# Altium schematic sheet Schem, Transadmittance Amp_rev_E.SchDoc
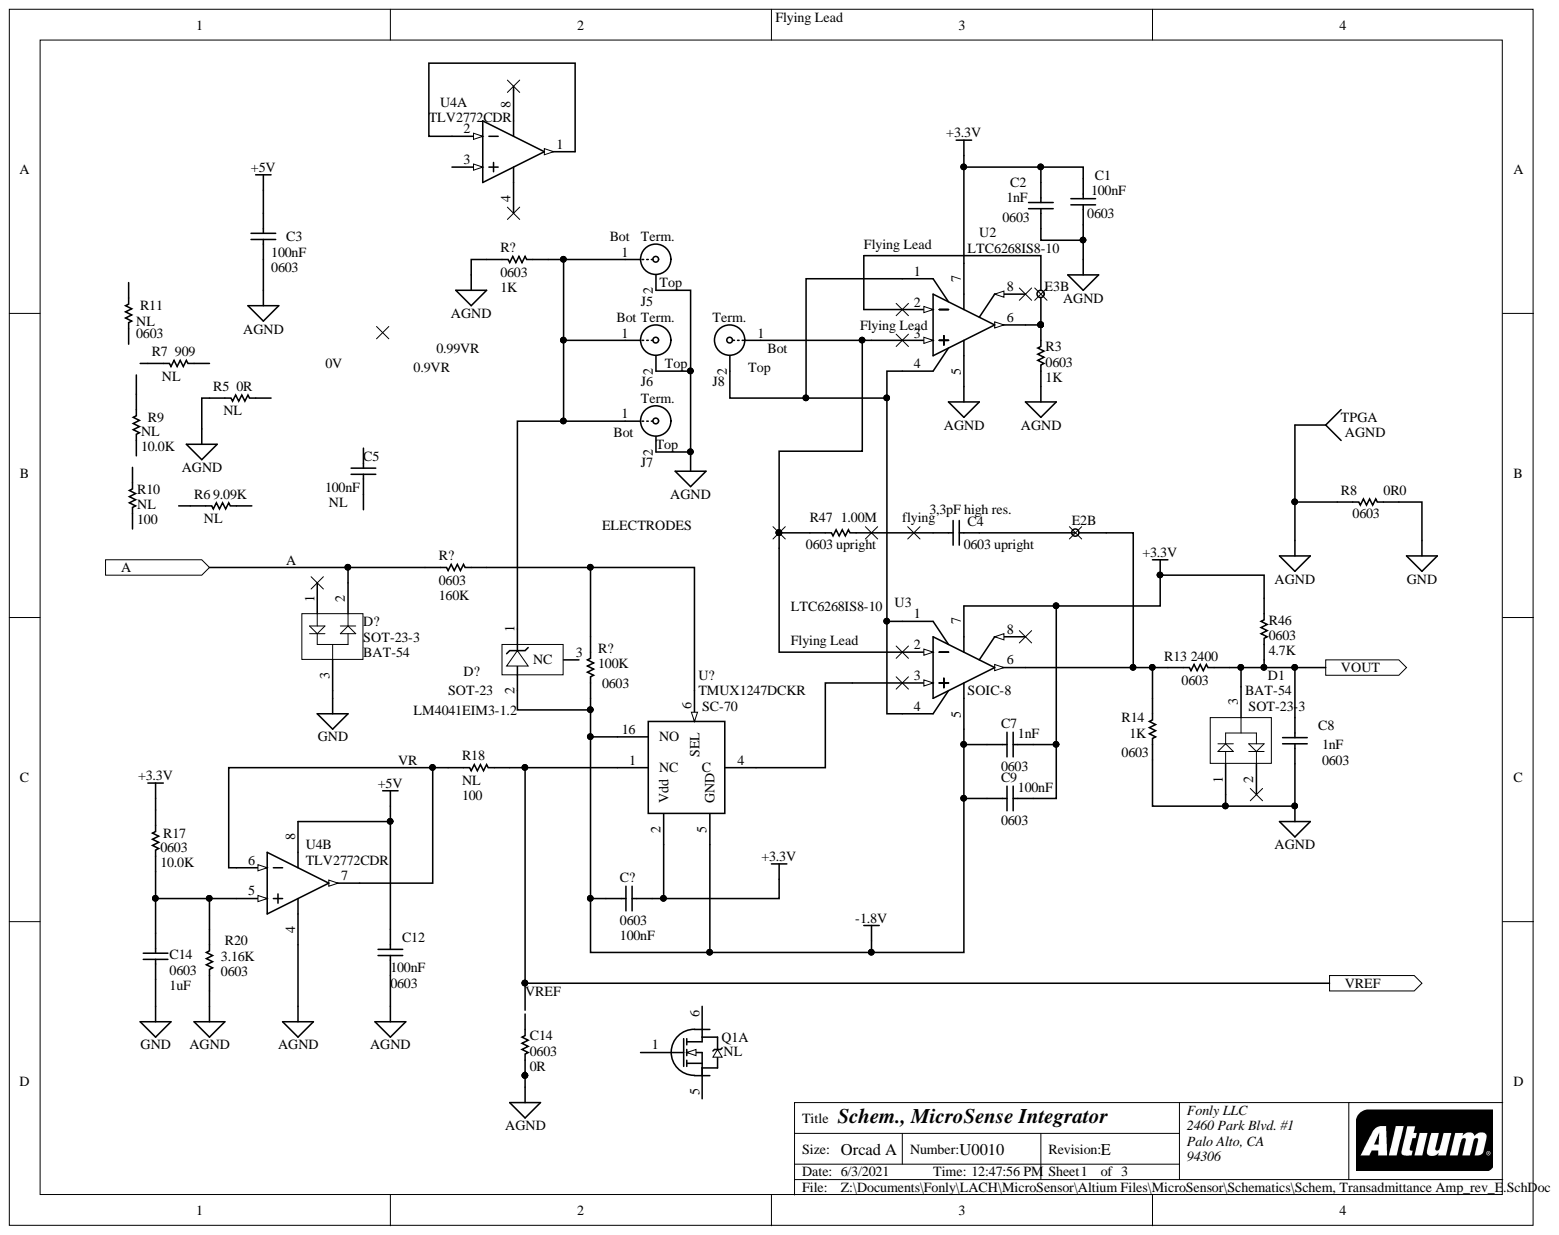
[diagram p0: Schem, Transadmittance Amp_rev_E.SchDoc]

## Parts
C1  0603  value 100nF  [1608[0603]]
C2  0603  value 1nF  [1608[0603]]
C3  0603  value 100nF  [1608[0603]]
C4  0603 upright  value 3,3pF high res.  [1608[0603]]
C5  NL  value 100nF  [1608[0603]]
C7  0603  value 1nF  [1608[0603]]
C8  0603  value 1nF  [1608[0603]]
C9  0603  value 100nF  [1608[0603]]
C12  0603  value 100nF  [1608[0603]]
C14  0603  value 0R  [RESC1608L]
C14  0603  value 1uF  [1608[0603]]
C?  0603  value 100nF  [1608[0603]]
D1  SOT-23-3  MFG_P/N: BAT-54  [DIOD-SOT23-3_V]
D?  SOT-23  [MF03A_N]
D?  SOT-23-3  MFG_P/N: BAT-54  [DIOD-SOT23-3_V]
E2  Socket  [SCKT-1.05H1]  (value not drawn)  (part B)
E3  Socket  [SCKT-1.05H1]  (value not drawn)  (part B)
J5  Coax endpoint  [Coaxial Terminal]  (value not drawn)
J6  Coax endpoint  [Coaxial Terminal]  (value not drawn)
J7  Coax endpoint  [Coaxial Terminal]  (value not drawn)
J8  Coax endpoint  [Coaxial Terminal]  (value not drawn)
Q1  NL  [SC-74]  (part A)
R3  0603  value 1K  [RESC1608L]
R5  NL  value 0R  [RESC1608L]
R6  NL  value 9.09K  [RESC1608L]
R7  NL  value 909  [RESC1608L]
R8  0603  value 0R0  [RESC1608L]
R9  NL  value 10.0K  [RESC1608L]
R10  NL  value 100  [RESC1608L]
R11  0603  value NL  [RESC1608L]
R13  0603  value 2400  [RESC1608L]
R14  0603  value 1K  [RESC1608L]
R17  0603  value 10.0K  [RESC1608L]
R18  NL  value 100  [RESC1608L]
R20  0603  value 3.16K  [RESC1608L]
R46  0603  value 4.7K  [RESC1608L]
R47  0603 upright  value 1.00M  [RESC1608L]
R?  0603  value 1K  [RESC1608L]
R?  0603  value 160K  [RESC1608L]
R?  0603  value 100K  [RESC1608L]
TPGA  AGND  [PIN1]
U2  MFG_P/N: LTC6268IS8-10  [LTC6268-SO8]  (hidden comment: SOIC-8)
U3  SOIC-8  MFG_P/N: LTC6268IS8-10  [LTC6268-SO8]
U4  TLV2772CDR  [D0008A_N]  (parts A,B)
U?  SC-70  PartNo: TMUX1247DCKR

## Ports
A, VOUT, VREF

## Nets
+3.3V: C1.1 C2.1 C7.2 C9.2 C?.2 R17.1 R46.1 U2.7 U3.7 U?.2(Vdd)
+5V: C3.1 C12.1 U4.8
-1.8V: C7.1 C9.1 C?.1 D?.2 R?.2 U3.5 U?.5(GND) U?.16(NO)
AGND: C1.2 C2.2 C3.2 C8.2 C12.2 C14.2 D1.1 J5.2 J6.2 J7.2 R3.2 R5.2 R8.1 R14.2 R20.2 R?.1 TPGA.1 U2.5 U4.4
GND: C14.2 D?.3 R8.2
A: D?.2 R?.1 ; port A
VOUT: C8.1 D1.3 R13.1 R46.2 ; port VOUT
VR: R18.1 U4.6 U4.7
VREF: R18.2 U?.1(NC) ; port VREF
NetC4_1: C4.1 R47.2
NetC14_1: C14.1 R17.2 R20.1 U4.5
NetD?_1: D?.1 J5.1 J6.1 J7.1 R?.2
NetE2_1: E2.1 R13.2 R14.1 U3.6
NetE3_1: E3.1 R3.1 U2.6
NetJ8_1: J8.1 R47.1
NetJ8_2: J8.2 U2.1 U2.4 U3.1 U3.4
NetR?_1: R?.1 R?.2 U?.6(SEL)
NetU4_1: U4.1 U4.2
0.9VR:
0.99VR:
0V:

Not connected: C4 2; C5 1 2; D1 2; Q1 1 5 6; R5 1; R6 1 2; R7 1 2; R9 1 2; R10 1 2; R11 1 2; U2 2 3 8; U3 2 3 8; U4 3; U? 4(C)

## Library data of the parts
C1 C3 C5 C9 C12 C? = Cap Semi: Capacitor Ceramic, SMD, 0603, 0.1uF, 16V, 10%; MFG: Samsung; MFG_P/N: CL10B104KO8NNWC; Vendor: DigiKey; Vendor P/N: 1276-1939-1-ND; Component Kind: Standard; Library Name: Miscellaneous Devices.SchLib; Signal Integrity: Cap Semi; Simulation: CAP
C2 C7 C8 = Cap Semi: Capacitor Ceramic, SMD, 0603, C0G, 1nF, 50V, 5%; MFG: Samsung; MFG_P/N: CL10C102JB8NFNC; Vendor: DigiKey; Vendor P/N: 1276-2170-1-ND; Component Kind: Standard; Library Name: Miscellaneous Devices.SchLib; Signal Integrity: Cap Semi; Simulation: CAP
C4 = Cap Semi: Cap., ceramic, ultra-lo ESR, 3.3pF 10^12 ohm; MFG: AVX; MFG_P/N: MK05V7270JAT2A; Vendor: Mouser; Vendor P/N: 581-MK05V7270JAT2A; Component Kind: Standard; Library Name: Miscellaneous Devices.SchLib; Signal Integrity: Cap Semi; Simulation: CAP
C14 R5 = Res1: Resistor; MFG: Panasonic; MFG_P/N: ERJ-3GEY0R00V; Vendor: DigiKey; Vendor2: Mouser; Vendor2 P/N: 667-ERJ-3EKF9092V; Vendor P/N: P0.0GCT-ND; Component Kind: Standard; Library Name: Miscellaneous Devices.SchLib; Signal Integrity: Res; Simulation: RESISTOR
C14 = Cap Semi: Capacitor Ceramic, SMD, 0603, .01uF, 16V, 10%; MFG: Kemet; MFG_P/N: C0603C105M3RACTU; Vendor: DigiKey; Vendor P/N: 399-7377-1-ND; Component Kind: Standard; Library Name: Miscellaneous Devices.SchLib; Signal Integrity: Cap Semi; Simulation: CAP
D1 D? = CMP-1667-00006-1: Surface Mount Schottky Barrier Diode, -65 to 150 degC, 3-Pin SOT23, RoHS, Tape and Reel; Colour: Orange; MFG: Diodes Inc.; Vendor: DigiKey; Vendor P/N: BAT54S-FDICT-ND; Component Kind: Standard; ComponentLink1Description: Manufacturer URL; ComponentLink1URL: http://www.diodes.com/; ComponentLink2Description: Datasheet; ComponentLink2URL: http://www.diodes.com/_files/datasheets/ds11005.pdf; Contact Material: Bronze; Contact Plating: Tin; DatasheetVersion: Rev. 31-2, 10/2013; Diameter: 2.54 mm; Height: 4.57 mm; Length: 7.62 mm; Library Name: Altium Content Vault; Mount: Through Hole; PackageDescription: 3-Pin Small Outline Transistor, Body 2.9 x 1.3 mm, Pitch 0.95 mm; Package Quantity: 10; PackageReference: SOT23-3; Packaging: Bulk; Pins: 1; REACH SVHC: No SVHC; RoHSCompliant: true
D? = LM4041EIM3-1.2: Precision Micropower Shunt Voltage Reference, 3-pin SOT-23; Manufacturer: National Semiconductor; CompType: Voltage Reference IC; PackageTopMarking: R1E*XTT; PowerWise: N; Component Kind: Standard; ComponentLink1Description: LM4041 Datasheet; ComponentLink1URL: http://www.national.com/ds/LM/LM4041.pdf; ComponentLink2Description: LM4041 Web Page; ComponentLink2URL: http://www.national.com/pf/LM/LM4041.html; ComponentLink3Description: MF03A Package Page; ComponentLink3URL: http://www.national.com/packaging/folders/mf03a.html; DatasheetVersion: Mar-2005; Library Name: NSC Voltage Reference.SchLib; PackageDescription: Molded SOT-23, .115 x .051 x .037in Body, 3 Lead, .075 in Pitch; PackageReference: MF03A; PackageVersion: revB, Mar-2003; PartNo: LM4041EIM3-1.2; PartNumber: LM4041EIM3-1.2; PinCount: 3; Published: 1-Mar-2010; RoHS: N; Supplier 1: Digi-Key; Supplier 2: Digi-Key; Publisher: Altium Limited
J5-J8 = Coaxial Term: Coaxial pattern for 375mil outer spacers, connected (M-F) standoff ctr.; Component Kind: Standard; Library Name: Current Miscellaneous Connectors.SchLib
Q1 = Dual N/PMOS: MFR: Infineon; Component Kind: Standard; Library Name: Miscellaneous Devices.SchLib
R3 R14 R? = Res1: Resistor; MFG: Panasonic; MFG_P/N: ERJ-3EKF1001V; Vendor: DigiKey; Vendor P/N: P1.00KHCT-ND; Component Kind: Standard; Library Name: Miscellaneous Devices.SchLib; Signal Integrity: Res; Simulation: RESISTOR
R6 = Res1: Resistor; MFG: Panasonic; MFG_P/N: ERJ-3EKF9091V; Vendor: DigiKey; Vendor2: Mouser; Vendor2 P/N: 667-ERJ-3EKF9092V; Vendor P/N: P9.09KHCT-ND; Component Kind: Standard; Library Name: Miscellaneous Devices.SchLib; Signal Integrity: Res; Simulation: RESISTOR
R7 = Res1: Resistor; MFG: Panasonic; MFG_P/N: ERJ-3EKF9090V; Vendor: DigiKey; Vendor2: Mouser; Vendor2 P/N: 667-ERJ-3EKF9092V; Vendor P/N: P909HCT-ND; Component Kind: Standard; Library Name: Miscellaneous Devices.SchLib; Signal Integrity: Res; Simulation: RESISTOR
R8 = Res1: Resistor; MFG: Panasonic; MFG_P/N: ERJ-3GEY0R00V; Vendor: DigiKey; Vendor P/N: ERJ-3EKF49R9V; Component Kind: Standard; Library Name: Miscellaneous Devices.SchLib; Signal Integrity: Res; Simulation: RESISTOR
R9 R17 R47 = Res1: Resistor; MFG: Panasonic; MFG_P/N: ERJ-3EKF1002V; Vendor: DigiKey; Vendor P/N: P10.0KHCT-ND; Component Kind: Standard; Library Name: Miscellaneous Devices.SchLib; Signal Integrity: Res; Simulation: RESISTOR
R10 R18 = Res1: Resistor; MFG: Panasonic; MFG_P/N: ERJ-3EKF1000V; Vendor: DigiKey; Vendor P/N: P100HCT-ND; Component Kind: Standard; Library Name: Miscellaneous Devices.SchLib; Signal Integrity: Res; Simulation: RESISTOR
R11 = Res1: Resistor; MFG: Panasonic; Vendor: DigiKey; Vendor P/N: P10.0KHCT-ND; Component Kind: Standard; Library Name: Miscellaneous Devices.SchLib; Signal Integrity: Res; Simulation: RESISTOR
R13 = Res1: Resistor; MFG: Panasonic; MFG_P/N: ERJ-3EKF2401V; Vendor: DigiKey; Vendor2: Mouser; Vendor2 P/N: 667-ERJ-3EKF9092V; Vendor P/N: P92.40KHCT-ND; Component Kind: Standard; Library Name: Miscellaneous Devices.SchLib; Signal Integrity: Res; Simulation: RESISTOR
R20 = Res1: Resistor; MFG: Panasonic; MFG_P/N: ERJ-3EKF3160V; Vendor: DigiKey; Vendor P/N: P316HCT-ND; Component Kind: Standard; Library Name: Miscellaneous Devices.SchLib; Signal Integrity: Res; Simulation: RESISTOR
R46 = Res1: Resistor; MFG: Panasonic; MFG_P/N: ERJ-3EKF4701V; Vendor: DigiKey; Vendor P/N: P4.70KHCT-ND; Component Kind: Standard; Library Name: Miscellaneous Devices.SchLib; Signal Integrity: Res; Simulation: RESISTOR
R? = Res1: Resistor; MFG: Panasonic; MFG_P/N: ERJ-3EKF1603V; Vendor: DigiKey; Vendor P/N: P1.00KHCT-ND; Component Kind: Standard; Library Name: Miscellaneous Devices.SchLib; Signal Integrity: Res; Simulation: RESISTOR
R? = Res1: Resistor; MFG: Panasonic; MFG_P/N: ERJ-3EKF1003V; Vendor: DigiKey; Vendor P/N: P1.00KHCT-ND; Component Kind: Standard; Library Name: Miscellaneous Devices.SchLib; Signal Integrity: Res; Simulation: RESISTOR
TPGA = Socket: Component Kind: Standard; Description: Socket; LatestRevisionDate: 17-Jul-2002; LatestRevisionNote: Re-released for DXP Platform.; Library Name: Current Miscellaneous Connectors.SchLib; Signal Integrity: Connector; Publisher: Altium Limited
U2 U3 = LTC6268-10: Op Amp, low input current; MFG: Analog Devices; Vendor: DigiKey; Vendor P/N: LTC6268IS8-10#PBF-ND; Component Kind: Standard; Library Name: LT Operational Amplifier.SchLib
U4 = CMP-0272-03014-3: Dual High-Slew-Rate Rail-to-Rail Output Operational Amplifier, 2.5 to 6 V, 0 to 70 degC, 8-pin SOIC (D8), Green (RoHS & no Sb/Br); MFG: TI; Vendor: DigiKey; Vendor P/N: 296-10677-1-ND; Component Kind: Standard; ComponentLink1Description: Manufacturer URL; ComponentLink1URL: http://www.ti.com/; ComponentLink2Description: Datasheet; ComponentLink2URL: http://www.ti.com/lit/ds/symlink/tlv2772.pdf; ComponentLink3Description: Package Specification; ComponentLink3URL: http://www.ti.com/litv/pdf/msoi002j; Library Name: Altium Content Vault; MFG_P/N: TLV2772CDR
U? = TMUX1247: Analog Bidirectional Multiplexer, 2:1; Component Kind: Standard; Library Name: TI Logic Switch.SchLib
E2 E3 = Socket: Component Kind: Standard; Description: Socket; LatestRevisionDate: 17-Jul-2002; LatestRevisionNote: Re-released for DXP Platform.; Library Name: Current Miscellaneous Connectors.SchLib; Signal Integrity: Connector; Publisher: Altium Limited
